# Altium schematic sheet 12V dc-dc converter.SchDoc
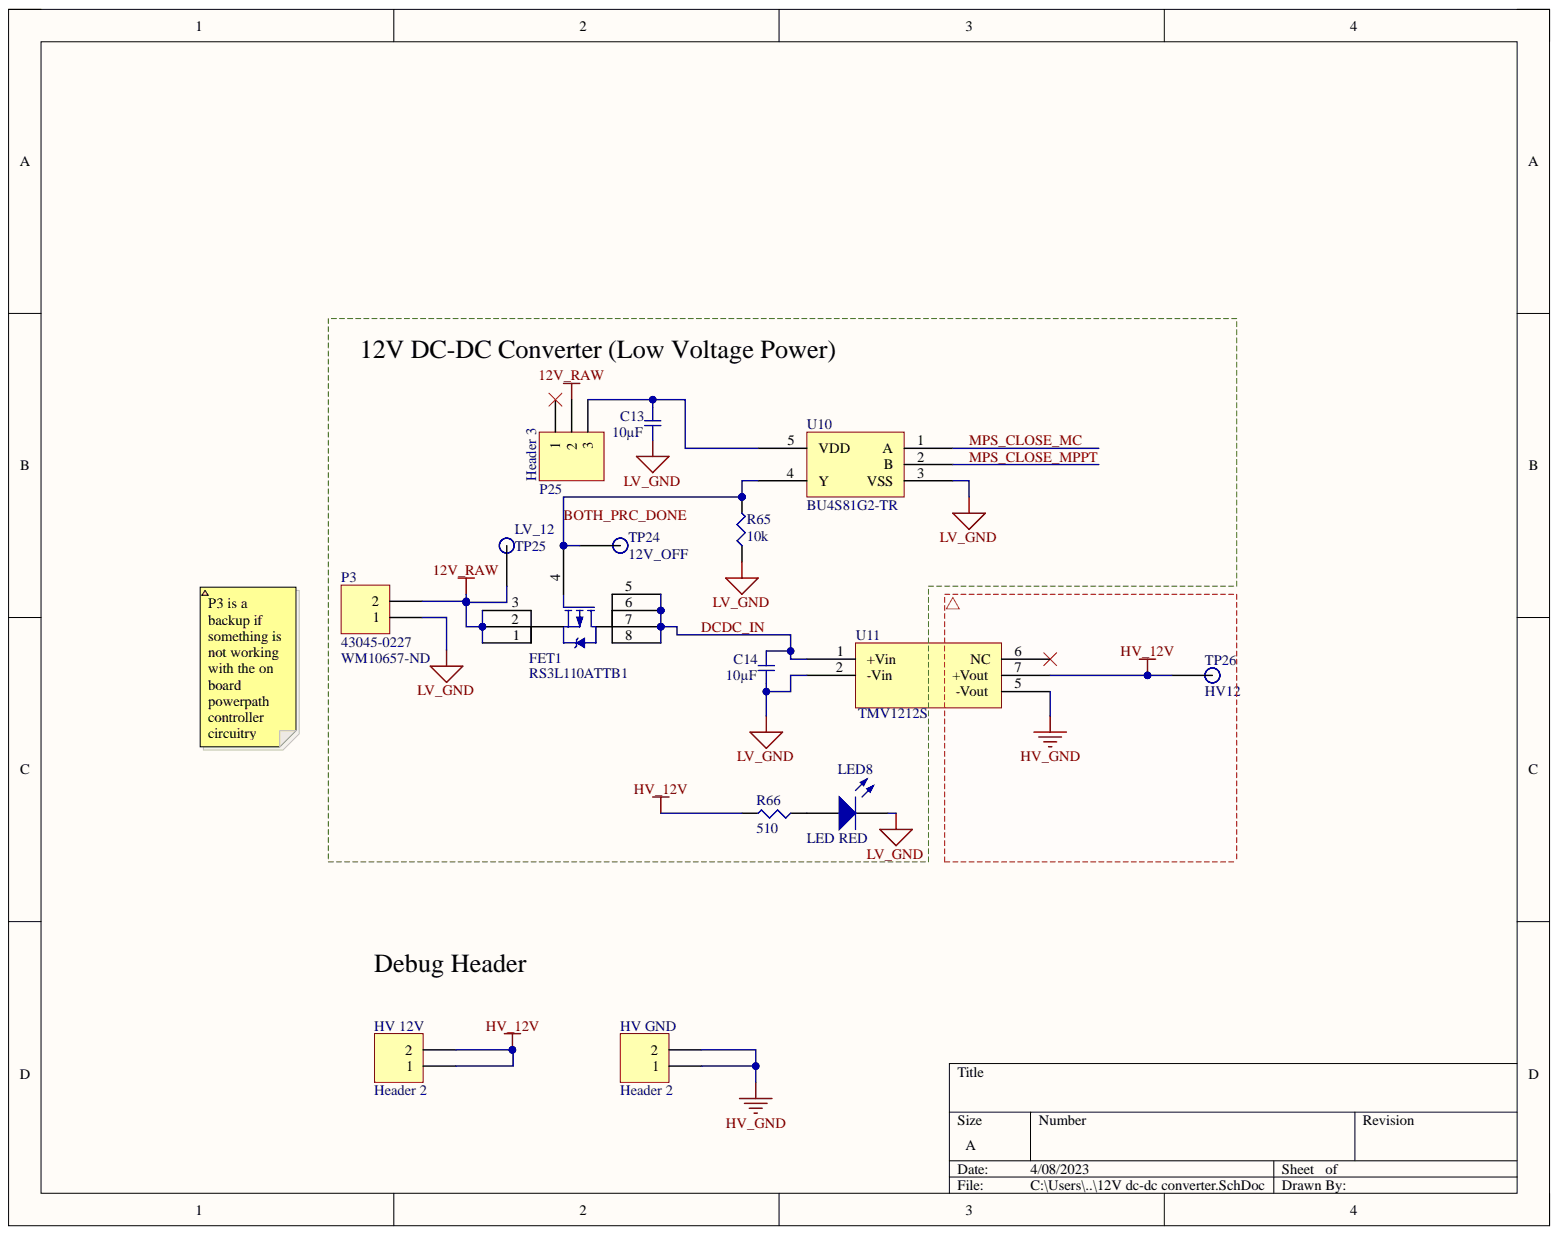
[diagram p0: 12V dc-dc converter.SchDoc]

## Parts
C13  value 10µF  [SMD-0805C]  (hidden comment: GCM21BR71A106KE22L)
C14  value 10µF  [SMD-0805C]  (hidden comment: GCM21BR71A106KE22L)
FET1  RS3L110ATTB1  [RS3L110AT]
HV_12V  Header 2  [Pin Header 1x2 TH Pitch 2.54mm]
HV_GND  Header 2  [Pin Header 1x2 TH Pitch 2.54mm]
LED8  LED RED  [LED 0603 ORANGE]
P3  43045-0227  Supplier Part Number 1: WM10657-ND  [43045-0277]
P25  Header 3  [Pin Header 1x3 TH Pitch 2.54mm]
R65  value 10k  [SMD-1206-RES]  (hidden comment: ERJ-8ENF1002V)
R66  value 510  [SMD-0805-RES]  (hidden comment: ERJ-P06J511V)
TP24  12V_OFF  [Test Point]
TP25  LV_12  [Test Point]
TP26  HV12  [Test Point]
U10  BU4S81G2-TR  [BU4S81G2]
U11  TMV1212S  [TMV1212S-Footprint-1]

## Nets
12V_RAW: FET1.1 FET1.2 FET1.3 P3.2 P25.2 TP25.1
HV_12V: HV_12V.1 HV_12V.2 R66.2 TP26.1 U11.7(+Vout)
HV_GND: HV_GND.1 HV_GND.2 U11.5(-Vout)
LV_GND: C13.1 C14.2 LED8.2 P3.1 R65.1 U10.3(VSS) U11.2(-Vin)
BOTH_PRC_DONE: FET1.4 R65.2 TP24.1 U10.4(Y)
DCDC_IN: C14.1 FET1.5 FET1.6 FET1.7 FET1.8 U11.1(+Vin)
MPS_CLOSE_MC: U10.1(A)
MPS_CLOSE_MPPT: U10.2(B)
NetC13_2: C13.2 P25.3 U10.5(VDD)
NetLED8_1: LED8.1 R66.1

Not connected: P25 1; U11 6(NC)

## Library data of the parts
C13 C14 = CMP-001-00205-1: CAP CER 10UF 10V X7R 0805; Manufacturer: Murata Electronics North America; @ qty: 0; Minimum Quantity: 1; Tolerance: 10%; Unit Price (USD): 0.49; Applications: Automotive; Component Kind: Standard; Datasheets: http://www.murata.com/~/media/webrenewal/support/library/catalog/products/capacitor/mlcc/c03e.ashx; Design Item ID: GCM21BR71A106KE22L; Digi-Key Part Number: 490-10674-1-ND; Factory Stock: 0; Features: -; Height - Seated (Max): -; Image: //media.digikey.com/photos/Murata%20Photos/NCP,GCM%20SERIES%200805.jpg; Lead Spacing: -; Lead Style: -; Library Name: Altium Concord Pro on A365; Mounting Type: Surface Mount, MLCC; Operating Temperature: -55°C ~ 125°C; Package / Case: 0805 (2012 Metric); Packaging: Cut Tape (CT); Part Number: GCM21BR71A106KE22L; Part Status: Active; Quantity Available: 125344; Ratings: AEC-Q200; Series: GCM; Source: capacitors.SchLib; Temperature Coefficient: X7R; Voltage: 10V
FET1 = CMP-008-000012-3: Transistor MOSFET P-Channel -60V ±11A 8-Pin SOP T/R; Transistor Type: P-channel MOSFET; Component Kind: Standard; Library Name: Altium Concord Pro on A365
HV_12V HV_GND = CMP-002-00072-1: Header, 2-Pin; Component Kind: Standard; Design Item ID: Header 2; LatestRevisionDate: 17-Jul-2002; LatestRevisionNote: Re-released for DXP Platform.; Library Name: Altium Concord Pro on A365; Source: connector.SchLib; Type: Connector; Publisher: Altium Limited
LED8 = CMP-040-000018-1: A-0603UOC; Manufacturer: Lighthouse LEDs; Color: ORANGE; Minimum Quantity: 1; Availability: In Stock; Component Kind: Standard; ComponentLink1Description: Manufacturer; ComponentLink1URL: https://lighthouseleds.com/0603-smd-led-orange-ultra-bright-170-mcd.html; Datasheets: https://lighthouseleds.com/downloads/dl/file/id/11/0603_orange_amber_smd_led_datasheet.pdf; Design Item ID: LED ORANGE 0603; Library Name: Altium Concord Pro on A365; Manufacturer P/N: A-0603UOC; Package / Case: 0603; Source: misc.SchLib
P3 = 43045-0227: Board Connector, 2 Contact(s), 2 Row(s), Male, Straight, Solder Terminal, Locking, Black Insulator, Receptacle; Manufacturer: Molex; Board Mounting Option: PEG; Body Breadth: 0.29inch; Body Depth: 0.39inch; Body Length: 0.262inch; Contact Resistance: 10mR; Contact Style: SQ PIN-SKT; DIN Conformance: No; Insertion Force-Max: 8.0064N; Insulation Resistance: 1GR; Mating Information: MULTIPLE MATING PARTS AVAILABLE; Number Of Connectors: 1; Number of Contacts: 2; Option: General Purpose; Plating Thickness: 6uin; Rated Current (Signal): 2.5A; Reference Standard: UL; Reliability: COMMERCIAL; Throw Configuration: S0P2; Withdrawl Force-Min: 3.5584N; Body/Shell Style: RECEPTACLE; Category: Headers and Wire Housings; Component Kind: Standard; ComponentLink1Description: https://datasheet.ciiva.com:443/pdfs/VipMasterIC/IC/MOLE/MOLE-S-A0001360181/MOLE-S-A0001399497-1.pdf?src-supplier=IHS+Markit; ComponentLink1URL: https://datasheet.ciiva.com:443/pdfs/VipMasterIC/IC/MOLE/MOLE-S-A0001360181/MOLE-S-A0001399497-1.pdf?src-supplier=IHS+Markit; ComponentLink2Description: https://datasheet.ciiva.com/5148/0430450227-pcb-headers-142611-5148694.pdf?src-supplier=Mouser; ComponentLink2URL: https://datasheet.ciiva.com/5148/0430450227-pcb-headers-142611-5148694.pdf?src-supplier=Mouser; ComponentLink3Description: https://datasheet.ciiva.com/16103/307170430450227-pcb-headers-16103122.pdf?src-supplier=Onlinecomponents.com; ComponentLink3URL: https://datasheet.ciiva.com/16103/307170430450227-pcb-headers-16103122.pdf?src-supplier=Onlinecomponents.com; ComponentLink4Description: https://datasheet.ciiva.com/11752/0900766b80d4a80b-11752890.pdf?src-supplier=RSComponents; ComponentLink4URL: https://datasheet.ciiva.com/11752/0900766b80d4a80b-11752890.pdf?src-supplier=RSComponents; ComponentLink5Description: https://datasheet.ciiva.com/30256/212010430450227-pcb-headers-30256881.pdf?src-supplier=Onlinecomponents.com; ComponentLink5URL: https://datasheet.ciiva.com/30256/212010430450227-pcb-headers-30256881.pdf?src-supplier=Onlinecomponents.com; ComponentLink6Description: https://datasheet.ciiva.com/16725/0430450227-pcb-headers-16725483.pdf?src-supplier=Avnet+Europe; ComponentLink6URL: https://datasheet.ciiva.com/16725/0430450227-pcb-headers-16725483.pdf?src-supplier=Avnet+Europe; ComponentLink7Description: https://datasheet.ciiva.com/10089/0430450227-pcb-headers-10089453.pdf?src-supplier=Avnet; ComponentLink7URL: https://datasheet.ciiva.com/10089/0430450227-pcb-headers-10089453.pdf?src-supplier=Avnet; Connector Type: BOARD CONNECTOR; Contact Finish - Mating: TIN (100) OVER NICKEL; Contact Finish - Termination: TIN (100) OVER NICKEL; Contact Gender: Male; Contact Material: Brass; Filter Feature: No; Flammability Rating: UL94 V-0; IEC Conformance: No; Insulation Color: Black; Insulation Material: Polymer; JESD-609 Code: e3; Library Name: connector.SchLib; Manufacturer Part Number: 43045-0227; Max Operating Temperature: 105°C; MIL Conformance: No; Min Operating Temperature: -40°C; Mixed Contacts: No; Mount: Board; Mounting Option 1: LOCKING; Mounting Style: STRAIGHT; Number of Rows Loaded: 2; Pricing 1: 1=0.97000, 10=0.80600, 80=0.67200, 945=0.53760, 2400=0.48720 (USD); REACH SVHC: Yes; RoHS: Yes; RoHS Compliant: Yes; Stock 1: 12970; Supplier 1: Digi-Key; Terminal Length: 0.125inch; Terminal Pitch: 3mm; Termination: Solder
P25 = CMP-002-00073-1: Header, 3-Pin; Component Kind: Standard; Design Item ID: Header 3; LatestRevisionDate: 17-Jul-2002; LatestRevisionNote: Re-released for DXP Platform.; Library Name: Altium Concord Pro on A365; Source: connector.SchLib; Type: Connector; Publisher: Altium Limited
R65 = CMP-009-01876-1: RES SMD 10K OHM 1% 1/4W 1206; Manufacturer: Panasonic Electronic Components; @ qty: 1500; Factory Stock: 0; Minimum Quantity: 1; Power (Watts): 0.25W, 1/4W; Temperature Coefficient: ±100ppm/°C; Unit Price (USD): 0.00933; Component Kind: Standard; Composition: Thick Film; Datasheets: http://industrial.panasonic.com/www-cgi/jvcr13pz.cgi?E+PZ+3+AOA0002+ERJ8ENF1002V+7+WW; Design Item ID: ERJ-8ENF1002V; Digi-Key Part Number: P10.0KFCT-ND; Failure Rate: -; Features: Automotive AEC-Q200; Height - Seated (Max): 0.028" (0.70mm); Image: //media.digikey.com/Renders/Panasonic%20Renders/ERJ-1206%20Pkg.jpg; Library Name: Altium Concord Pro on A365; Number of Terminations: 2; Operating Temperature: -55°C ~ 155°C; Package / Case: 1206 (3216 Metric); Packaging: Cut Tape (CT); Part Number: ERJ-8ENF1002V; Part Status: Active; Quantity Available: 1108398; Series: ERJ; Size / Dimension: 0.126" L x 0.063" W (3.20mm x 1.60mm); Source: resistors.SchLib; Supplier Device Package: 1206; Tolerance: 1%
R66 = CMP-009-00645-1: RES SMD 510 OHM 5% 1/2W 0805; Manufacturer: Panasonic Electronic Components; @ qty: 1500; Factory Stock: 0; Minimum Quantity: 1; Power (Watts): 0.5W, 1/2W; Temperature Coefficient: ±200ppm/°C; Unit Price (USD): 0.01839; Component Kind: Standard; Composition: Thick Film; Datasheets: https://industrial.panasonic.com/cdbs/www-data/pdf/RDA0000/AOA0000C243.pdf; Design Item ID: ERJ-P06J511V; Digi-Key Part Number: P510ADCT-ND; Failure Rate: -; Features: Automotive AEC-Q200, Pulse Withstanding; Height - Seated (Max): 0.028" (0.70mm); Image: //media.digikey.com/Renders/Panasonic%20Renders/ERJ-0805%20Pkg.jpg; Library Name: Altium Concord Pro on A365; Number of Terminations: 2; Operating Temperature: -55°C ~ 155°C; Package / Case: 0805 (2012 Metric); Packaging: Cut Tape (CT); Part Number: ERJ-P06J511V; Part Status: Active; Quantity Available: 54515; Series: ERJ; Size / Dimension: 0.079" L x 0.049" W (2.00mm x 1.25mm); Source: resistors.SchLib; Supplier Device Package: 0805; Tolerance: 5%
TP24-TP26 = CMP-039-000000-1: SMD TEST POINT; Component Kind: Standard; Design Item ID: TP; Library Name: Altium Concord Pro on A365; Source: misc.SchLib
U10 = CMP-017-000001-1: AND Gate, 4000/14000/40000 Series, 1-Func, 2-Input, CMOS, PDSO5; Manufacturer: Rohm; Load Capacitance (CL): 50pF; Number of Functions: 1; Number of Inputs: 2; Package Body Material: Plastic; Package Equivalence Code: TSOP5/6,.11,37; Power Supplies: 3/16V; Qualification Status: Not Qualified; Schmitt Trigger: No; Supply Voltage-Max (Vsup): 16V; Supply Voltage-Min (Vsup): 3V; Supply Voltage-Nom (Vsup): 5V; Category: Logic Gates; Component Kind: Standard; ComponentLink1Description: https://datasheet.ciiva.com:443/pdfs/VipMasterIC/IC/ROHM/ROHM-S-A0001749750/ROHM-S-A0001749750-1.pdf?src-supplier=IHS+Markit; ComponentLink1URL: https://datasheet.ciiva.com:443/pdfs/VipMasterIC/IC/ROHM/ROHM-S-A0001749750/ROHM-S-A0001749750-1.pdf?src-supplier=IHS+Markit; ComponentLink2Description: https://datasheet.ciiva.com/12254/bu4s81g2-e-209681-12254411.pdf?src-supplier=Mouser; ComponentLink2URL: https://datasheet.ciiva.com/12254/bu4s81g2-e-209681-12254411.pdf?src-supplier=Mouser; ComponentLink3Description: https://datasheet.ciiva.com/1508/bu4s81g2-e-1508101.pdf?src-supplier=Element14; ComponentLink3URL: https://datasheet.ciiva.com/1508/bu4s81g2-e-1508101.pdf?src-supplier=Element14; ComponentLink4Description: https://datasheet.ciiva.com/1508/bu4s81g2-e-1508102.pdf?src-supplier=Element14; ComponentLink4URL: https://datasheet.ciiva.com/1508/bu4s81g2-e-1508102.pdf?src-supplier=Element14; ComponentLink5Description: https://datasheet.ciiva.com/8274/bu4s01g2-e-8274597.pdf?src-supplier=Avnet; ComponentLink5URL: https://datasheet.ciiva.com/8274/bu4s01g2-e-8274597.pdf?src-supplier=Avnet; ComponentLink6Description: https://datasheet.ciiva.com/6619/bu4s01g2-e-6619800.pdf?src-supplier=Digi-Key; ComponentLink6URL: https://datasheet.ciiva.com/6619/bu4s01g2-e-6619800.pdf?src-supplier=Digi-Key; ComponentLink7Description: https://datasheet.ciiva.com/6619/bu4s01g2-e-6619813.pdf?src-supplier=Digi-Key; ComponentLink7URL: https://datasheet.ciiva.com/6619/bu4s01g2-e-6619813.pdf?src-supplier=Digi-Key; ComponentLink8Description: https://datasheet.ciiva.com/6619/bu4s01g2-e-6619814.pdf?src-supplier=Digi-Key; ComponentLink8URL: https://datasheet.ciiva.com/6619/bu4s01g2-e-6619814.pdf?src-supplier=Digi-Key; ComponentLink9Description: https://datasheet.ciiva.com/16678/bu4s01g2-e-16678569.pdf?src-supplier=Avnet+Europe; ComponentLink9URL: https://datasheet.ciiva.com/16678/bu4s01g2-e-16678569.pdf?src-supplier=Avnet+Europe; Design Item ID: BU4S81G2-TR; Height - Seated (Max): 1.25mm; JESD-30 Code: R-PDSO-G5; Length: 2.9mm; Library Name: Altium Concord Pro on A365; Logic IC Type: AND GATE; Manufacturer Part Number: BU4S81G2-TR; Max Operating Temperature: 85°C; Min Operating Temperature: -40°C; Moisture Sensitivity Level: 1; Number of Pins: 5; Package Code: LSSOP; Package Shape: Rectangular; Package Style: SMALL OUTLINE, LOW PROFILE, SHRINK PITCHMeter; Packaging: Tape and Reel; Pricing 1: 1=0.90000, 10=0.79200, 25=0.74400, 100=0.60720, 250=0.56396, 500=0.47996, 1000=0.38397 (USD); REACH SVHC: Yes; RoHS: Yes; RoHS Compliant: Yes; Source: ic.SchLib; Stock 1: 2698; Surface Mount: Yes; Technology: CMOS; Temperature Grade: INDUSTRIAL; Terminal Form: Gull Wing; Terminal Pitch: 0.95mm; Terminal Position: DUAL; Width: 1.6mm
U11 = CMP-008-000009-3: DC-DC Regulated Power Supply Module, 1 Output, 1W, Hybrid; Component Kind: Standard; Library Name: Altium Concord Pro on A365
